# Altium schematic sheet IC901_ToneEnc.SchDoc
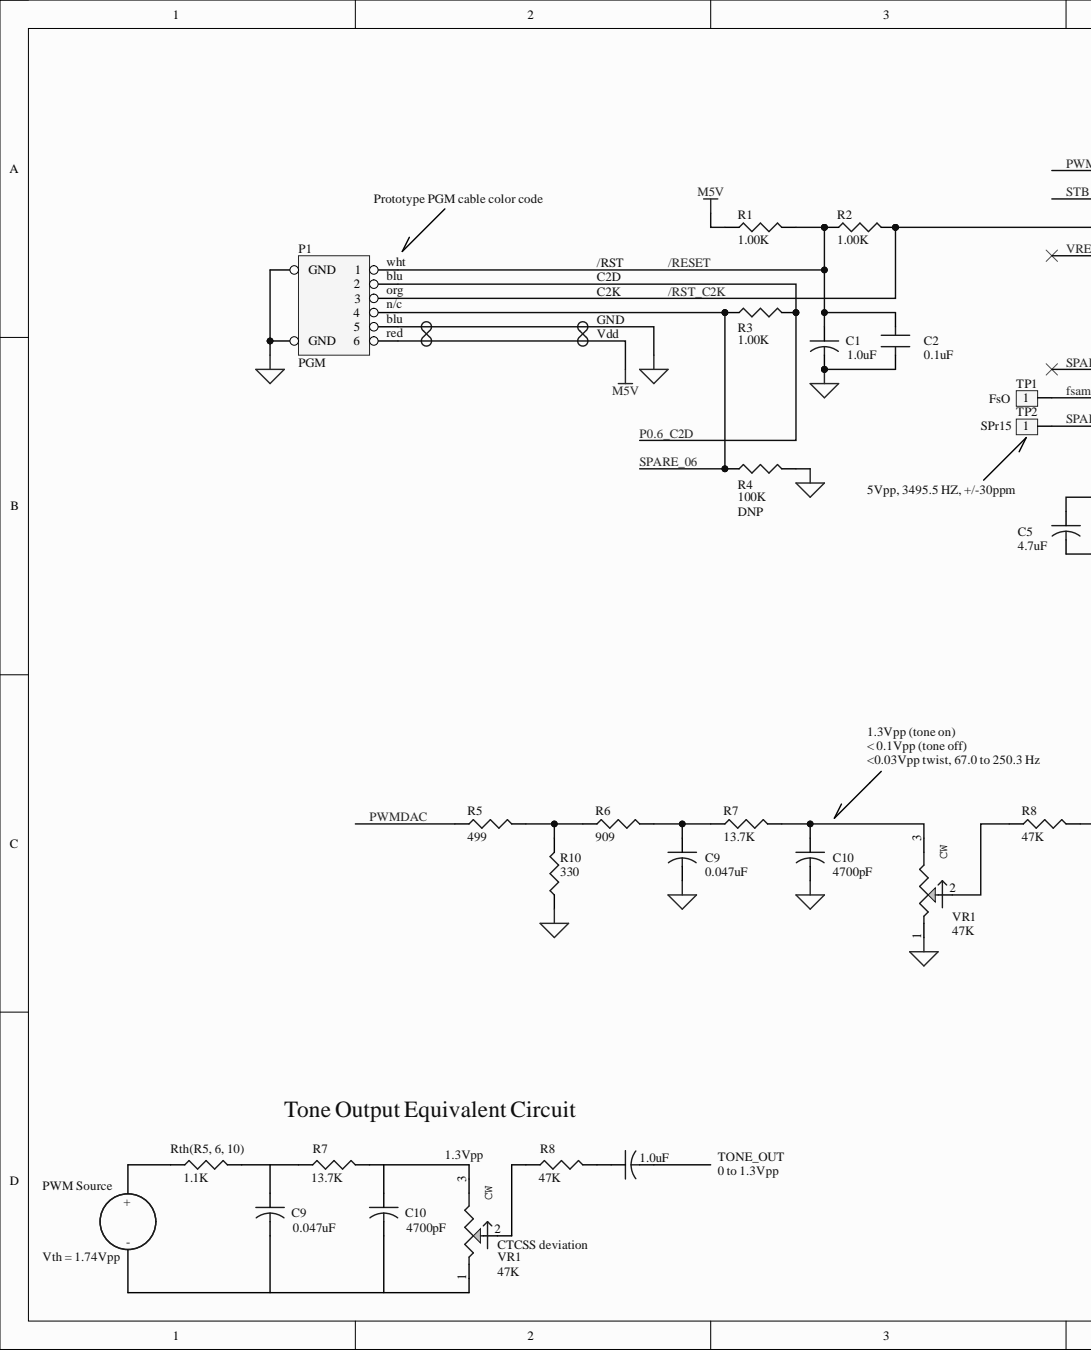
[diagram p0: IC901_ToneEnc.SchDoc, left]
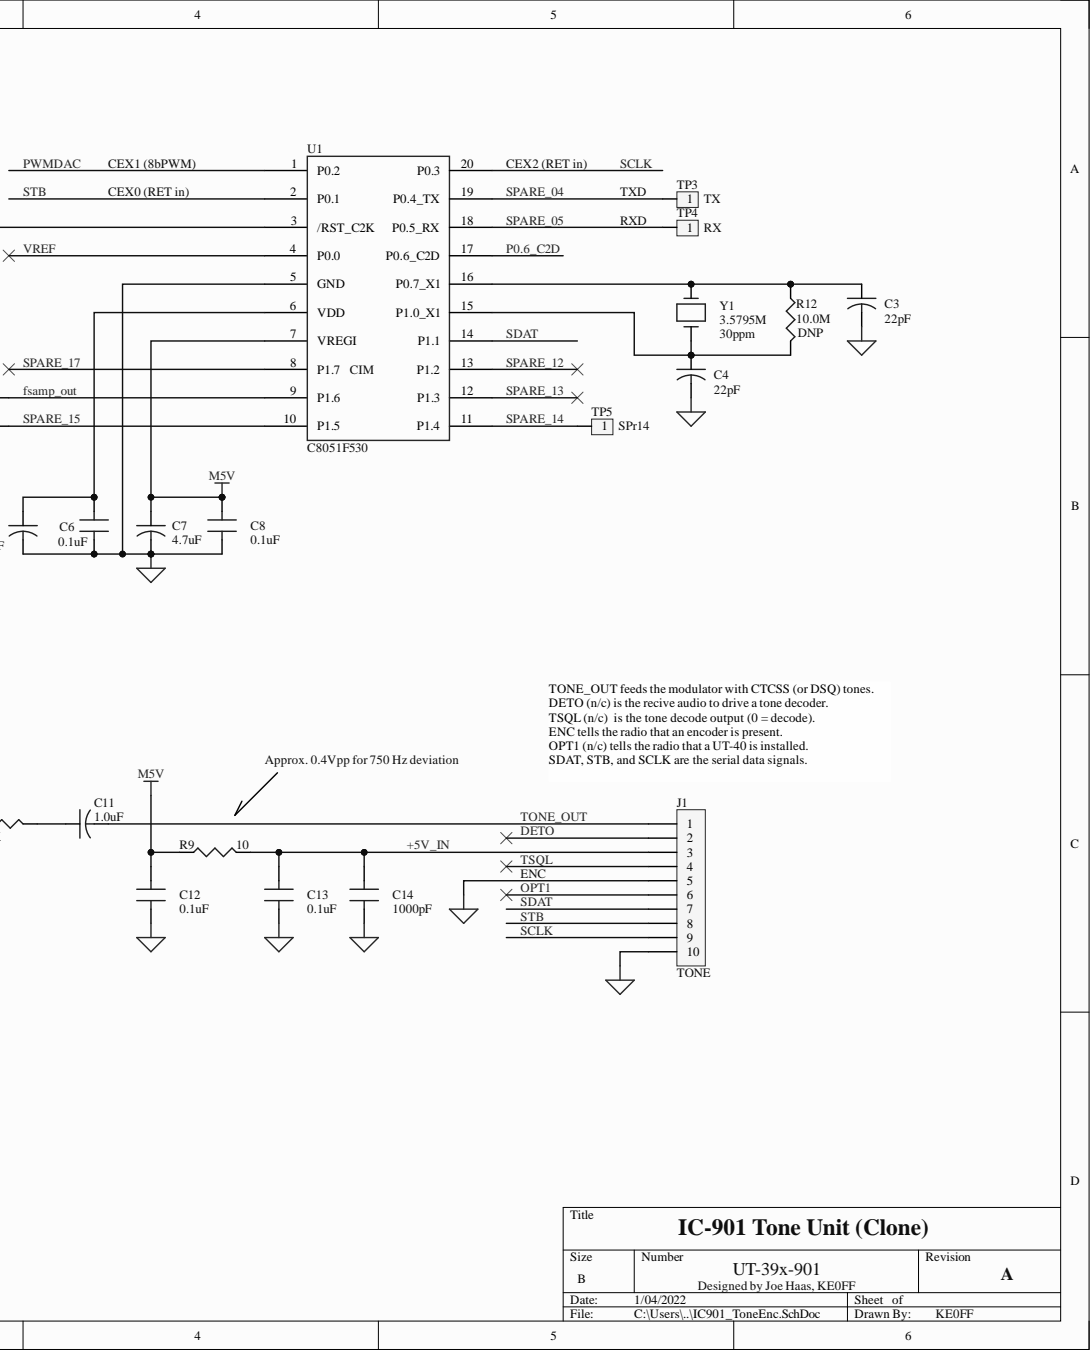
[diagram p1: IC901_ToneEnc.SchDoc, right]

## Parts
C1  1.0uF  [0805]
C2  0.1uF  [0402]
C3  22pF  [0402]
C4  22pF  [0402]
C5  4.7uF  [0805]
C6  0.1uF  [0402]
C7  4.7uF  [0805]
C8  0.1uF  [0402]
C9  0.047uF  [1206]
C10  4700pF  [0805]
C11  1.0uF  [0805]
C12  0.1uF  [0402]
C13  0.1uF  [0402]
C14  1000pF  [0402]
Ce1  0.047uF  [1206]
Ce2  4700pF  [0805]
J1  TONE  [MOLEX_1.5mm_SMD_10POS]
P1  PGM  [CON_1.25MM_6P]
R1  1.00K  [0402]
R2  1.00K  [0402]
R3  1.00K  [0402]
R4  100K  [0402]
R5  499  [0402]
R6  909  [0402]
R7  13.7K  [0402]
R8  47K  [0402]
R9  10  [1206]
R10  330  [0402]
R12  10.0M  [0402]
TP1  FsO  [TP-SMD-20x50-SQ]  (no BOM)
TP2  SPr15  [TP-SMD-20x50-SQ]  (no BOM)
TP3  TX  [TP-SMD-20x50-SQ]  (no BOM)
TP4  RX  [TP-SMD-20x50-SQ]  (no BOM)
TP5  SPr14  [TP-SMD-20x50-SQ]  (no BOM)
U1  C8051F530  [TSSOP-20]
VR1  47K  [POT_3313]
Y1  3.5795M  Stability: 30ppm  [XTAL_AT49_SMD]

## Nets
GND: C1.2 C2.2 C3.2 C4.2 C5.2 C6.2 C7.2 C8.2 C9.2 C10.2 C12.2 C13.2 C14.2 J1.5 J1.10 P1.5 P1.7(GND) P1.8(GND) R4.1 R10.2 U1.5(GND) VR1.1
M5V: C7.1 C8.1 C12.1 P1.6 R1.2 R9.2 U1.7(VREGI)
+5V_IN: C13.1 C14.1 J1.3 R9.1
/RESET: C1.1 C2.1 P1.1 R1.1 R2.2
/RST_C2K: P1.3 R2.1 U1.3(/RST_C2K)
DETO: J1.2
fsamp_out: TP1.1 U1.9(P1.6)
OPT1: J1.6
P0.6_C2D: P1.2 R3.1 U1.17(P0.6_C2D)
PWMDAC: R5.2 U1.1(P0.2)
SCLK: J1.9 U1.20(P0.3)
SDAT: J1.7 U1.14(P1.1)
SPARE_04: TP3.1 U1.19(P0.4_TX)
SPARE_05: TP4.1 U1.18(P0.5_RX)
SPARE_06: P1.4 R3.2 R4.2
SPARE_12: U1.13(P1.2)
SPARE_13: U1.12(P1.3)
SPARE_14: TP5.1 U1.11(P1.4)
SPARE_15: TP2.1 U1.10(P1.5)
SPARE_17: U1.8(P1.7)
STB: J1.8 U1.2(P0.1)
TONE_OUT: C11.2 J1.1
TSQL: J1.4
VREF: U1.4(P0.0)
NetC3_1: C3.1 R12.1 U1.16(P0.7_X1) Y1.2
NetC4_1: C4.1 R12.2 U1.15(P1.0_X1) Y1.1
NetC5_1: C5.1 C6.1 U1.6(VDD)
NetC9_1: C9.1 R6.1 R7.2
NetC10_1: C10.1 R7.1 VR1.3
NetC11_1: C11.1 R8.1
NetR5_1: R5.1 R6.2 R10.1
NetR8_2: R8.2 VR1.2

Not connected: Ce1 1 2; Ce2 1 2

## Library data of the parts
C1 C11 = CAP 0805: CAP, CER, 0805, 1.0uF, 25V; MFR: Samsung; MPN: CL21B105KBFNNNF; Component Kind: Standard; VOLTAGE: 25V
C2 C6 C8 C12 C13 = CAP CER: CAP, CER, 0402, 0.1uF, 50V; MFR: AVX; MPN: 04025C104KAT2A; Component Kind: Standard
C3 C4 = CAP 0805: CAP, MLCC, NPO, 1%, 0402, 50V, 22pF; MFR: Murata; MPN: GCM1555C1H220FA16D; VOLTAGE: 50; Component Kind: Standard; Library Name: Galaxy SCH-jem-1.08.SchLib
C5 C7 = CAP 0805: CAP, CER, 0805, 4.7uF, 10V; MFR: TDK; MPN: C2012X7R1A475K125AC; Component Kind: Standard; VOLTAGE: 10V
C9 Ce1 = CAP 0805: CAP, CER, NPO, 0805, 50V, 47nF; MFR: Yageo; MPN: CC1206JKNPO9BN473; Component Kind: Standard; VOLTAGE: 50V
C10 Ce2 = CAP 0805: CAP, CER, 0805, NPO, 50V, 4700pF; MFR: Walsin; MPN: 0805N472J500CT; Component Kind: Standard; VOLTAGE: 50V
C14 = CAP CER: CAP, CER, 0402, 50V, 1000pF; MFR: Yageo; MPN: CC0402JRNPO9BN102; Component Kind: Standard
J1 = CON10: CON, 10POS, 1.5mm, RTANG, SMD; MFR: Molex; MPN: 87438-1043; Component Kind: Standard; Library Name: Galaxy SCH-jem-1.08.SchLib
P1 = HEADER-6-PIN-RT-ANG: CON, 6POS, 1.25MM, SMD; MFR: Molex; MPN: 53398-0671; ALTPN: 53398-0671 (V), or 53261-0671 (RA); Component Kind: Standard
R1-R3 = R0805: RES, CHP, 0402, 1%, 1K; MFR: Yageo; MPN: RC0402FR-071KL; Component Kind: Standard
R4 = R0805: RES, CHP, 0402, 1%, 100K; MFR: Yageo; MPN: RC0402FR-07100KL; Component Kind: Standard; DNP: DNP
R5 = R0805: RES, CHP, 0402, 1%, 499; MFR: Yageo; MPN: RC0402FR-07499RL; Component Kind: Standard
R6 = R0805: RES, CHP, 0402, 1%, 909; MFR: Yageo; MPN: RC0402FR-07909RL; Component Kind: Standard
R7 = R0805: RES, CHP, 0402, 1%, 13.7K; MFR: Yageo; MPN: RC0402FR-0713K7L; Component Kind: Standard
R8 = R0805: RES, CHP, 0402, 1%, 47K; MFR: Yageo; MPN: RC0402FR-0747KL; Component Kind: Standard
R9 = R0805: RES, CHP, 0402, 1%, 10.0; MFR: Yageo; MPN: RC1206FR-7W10RL; Component Kind: Standard
R10 = R0805: RES, CHP, 0402, 1%, 330; MFR: Yageo; MPN: RC0402FR-07330RL; Component Kind: Standard
R12 = R0805: RES, CHP, 0402, 1%, 10.0M; MFR: Yageo; MPN: RC0402FR-0710ML; Component Kind: Standard; DNP: DNP; Library Name: Galaxy SCH-jem-1.08.SchLib
TP1-TP5 = TP: Library Name: Galaxy SCH-jem-1.08.SchLib
U1 = C8051F531: IC, MCU, 8051, F531; MFR: SiLabs; MPN: C8051F531-C-IT; Component Kind: Standard
VR1 = RESISTOR VAR: RES, VAR, MICRO, SMD, 1T, 47K; MFR: Bourns; MPN: 3313X-1-503E; Component Kind: Standard; Library Name: Galaxy SCH-jem-1.08.SchLib
Y1 = CRYSTAL-2PIN: CRSTL, QRTZ, HC49U, <=50ppm; MFR: Abracon; MPN: ABLSG-3.579545MHZ-D2Y-T; Component Kind: Standard; Library Name: Galaxy SCH-jem-1.08.SchLib
